# Altium schematic sheet P03-UARTs_KEY.SchDoc
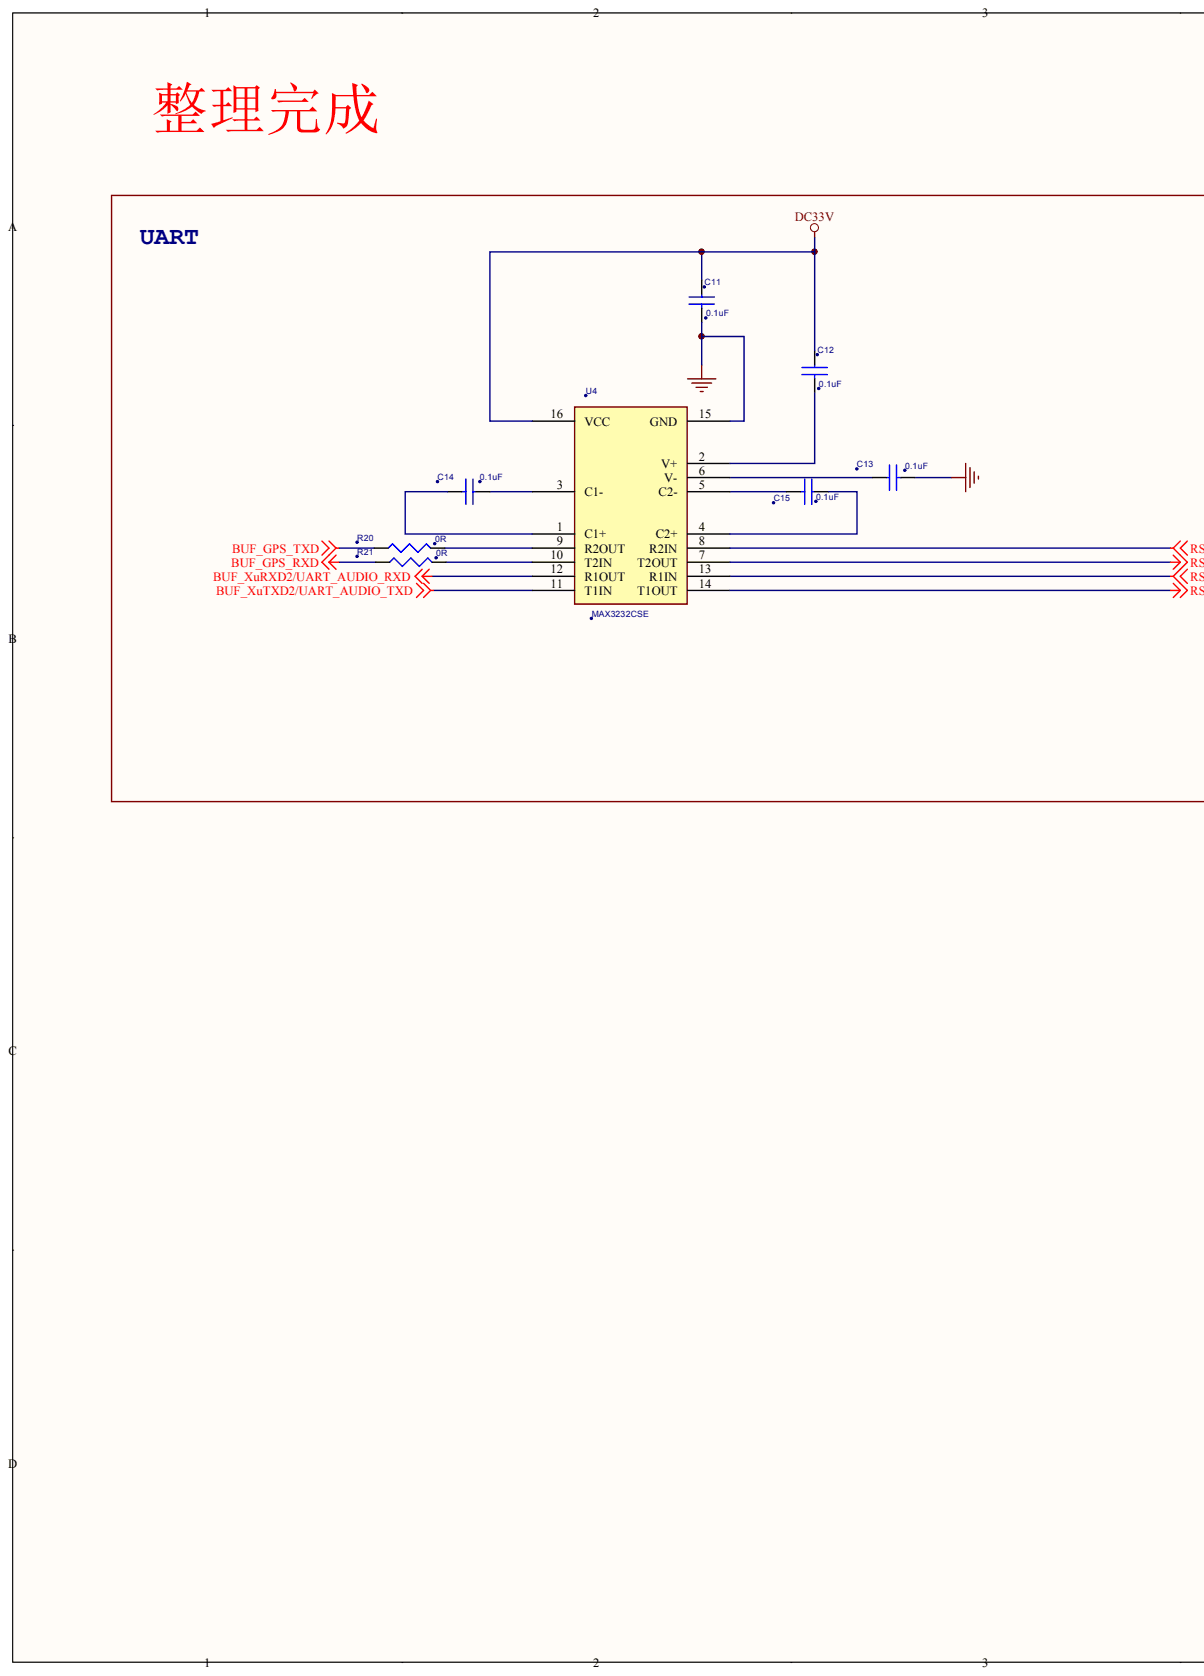
[diagram p0: P03-UARTs_KEY.SchDoc, left]
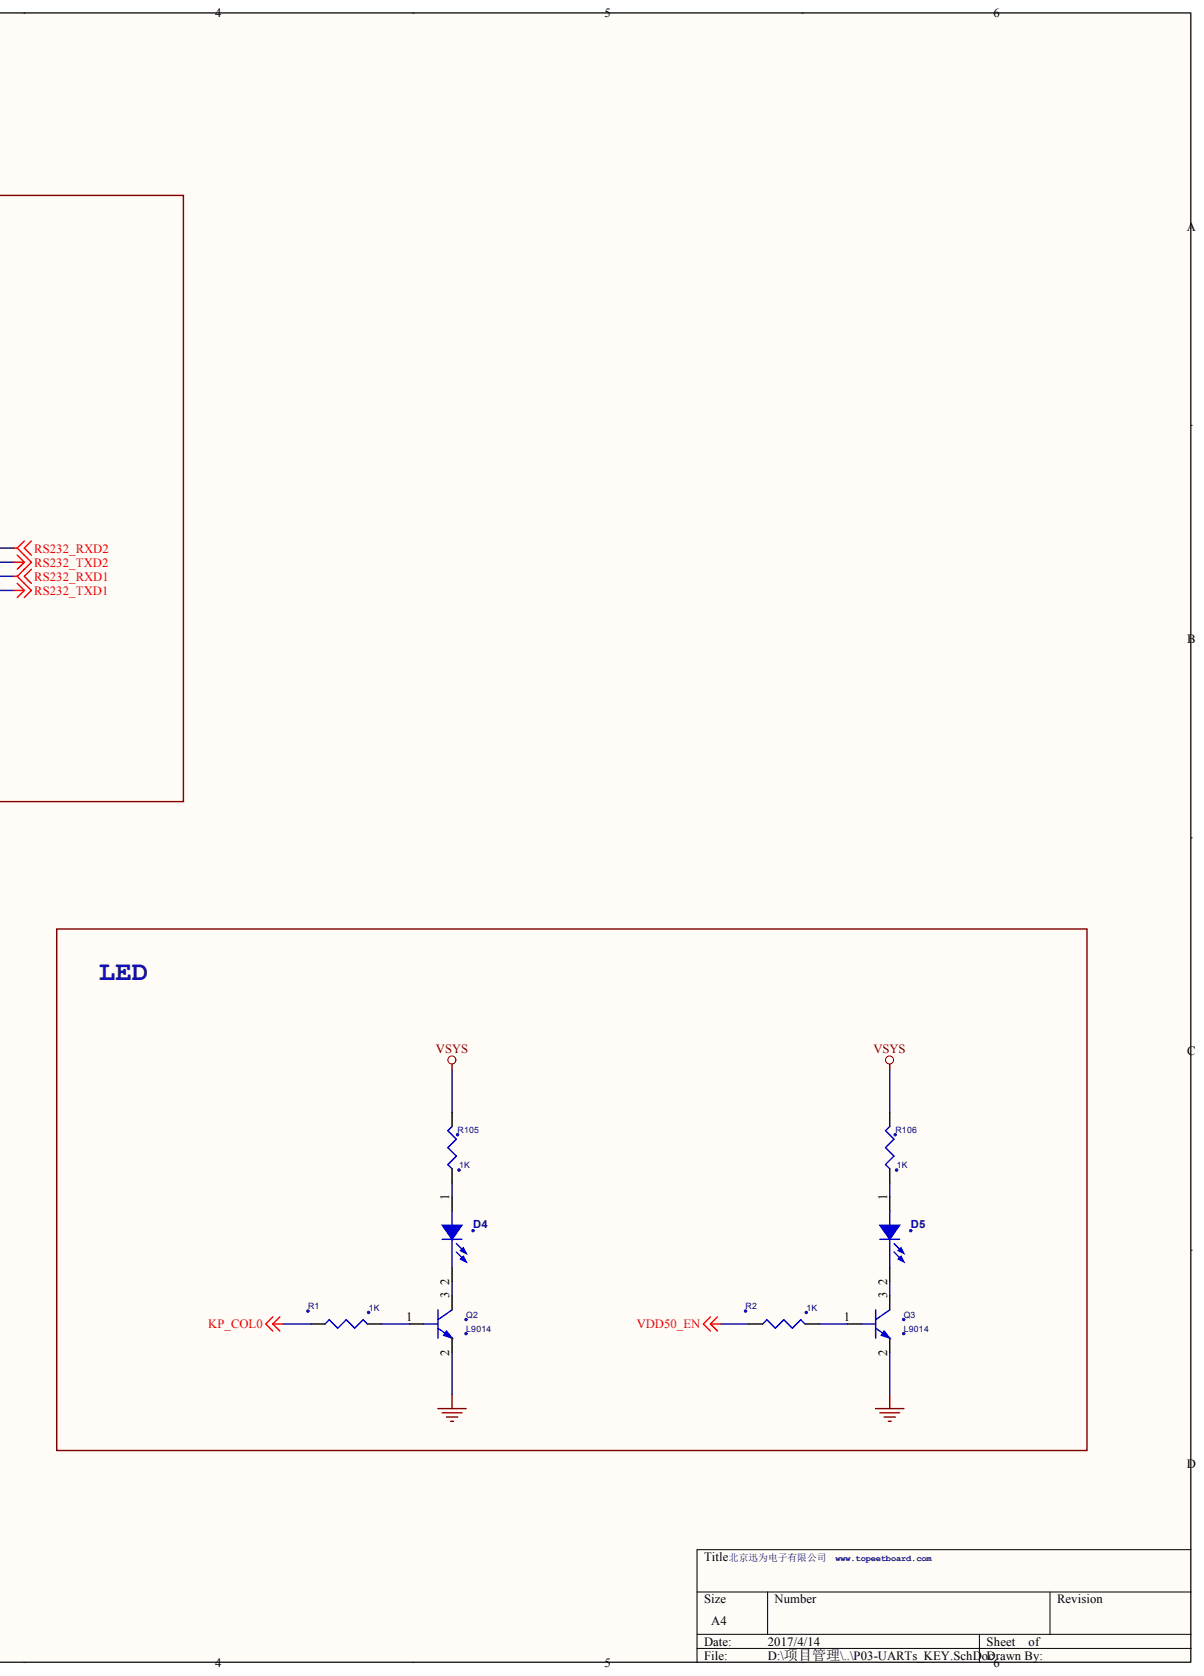
[diagram p1: P03-UARTs_KEY.SchDoc, right]

## Parts
C11  0.1uF
C12  0.1uF
C13  0.1uF
C14  0.1uF
C15  0.1uF
D4  LED-Red (SMD 2012)  (value not drawn)
D5  LED-Red (SMD 2012)  (value not drawn)
Q2  L9014
Q3  L9014
R1  1K
R2  1K
R20  0R
R21  0R
R105  1K
R106  1K
U4  MAX3232CSE

## Nets
BUF_GPS_RXD: R21.1
BUF_GPS_TXD: R20.1
BUF_XURXD2/UART_AUDIO_RXD: U4.12(R1OUT)
BUF_XUTXD2/UART_AUDIO_TXD: U4.11(T1IN)
DC33V: C11.1 C12.1 U4.16(VCC)
GND: C11.2 C13.2 Q2.2 Q3.2 U4.15(GND)
KP_COL0: R1.2
RS232_RXD1: U4.13(R1IN)
RS232_RXD2: U4.8(R2IN)
RS232_TXD1: U4.14(T1OUT)
RS232_TXD2: U4.7(T2OUT)
VDD50_EN: R2.2
VSYS: R105.2 R106.2
NetC12_2: C12.2 U4.2(V+)
NetC13_1: C13.1 U4.6(V-)
NetC14_1: C14.1 U4.3(C1-)
NetC14_2: C14.2 U4.1(C1+)
NetC15_1: C15.1 U4.4(C2+)
NetC15_2: C15.2 U4.5(C2-)
NetD4_1: D4.1 R105.1
NetD4_2: D4.2 Q2.3
NetD5_1: D5.1 R106.1
NetD5_2: D5.2 Q3.3
NetQ2_1: Q2.1 R1.1
NetQ3_1: Q3.1 R2.1
NetR20_2: R20.2 U4.9(R2OUT)
NetR21_2: R21.2 U4.10(T2IN)
